# Altium schematic sheet Sheet2.SchDoc
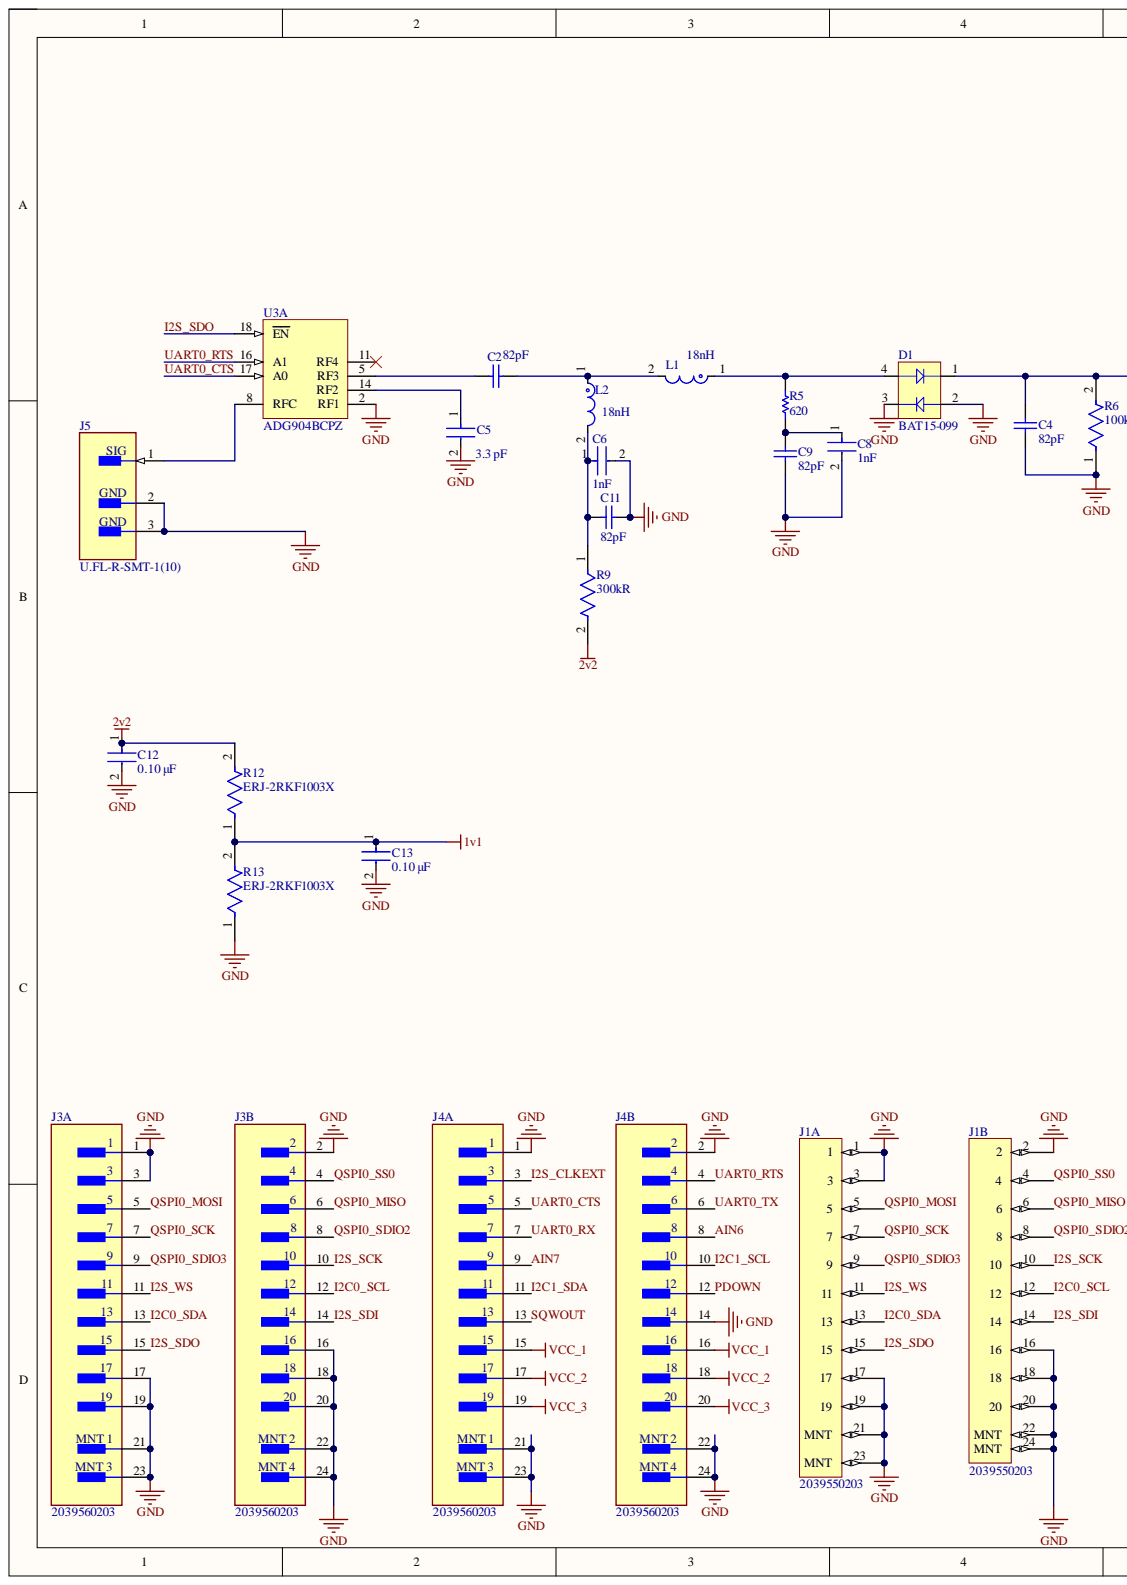
[diagram p0: Sheet2.SchDoc, left]
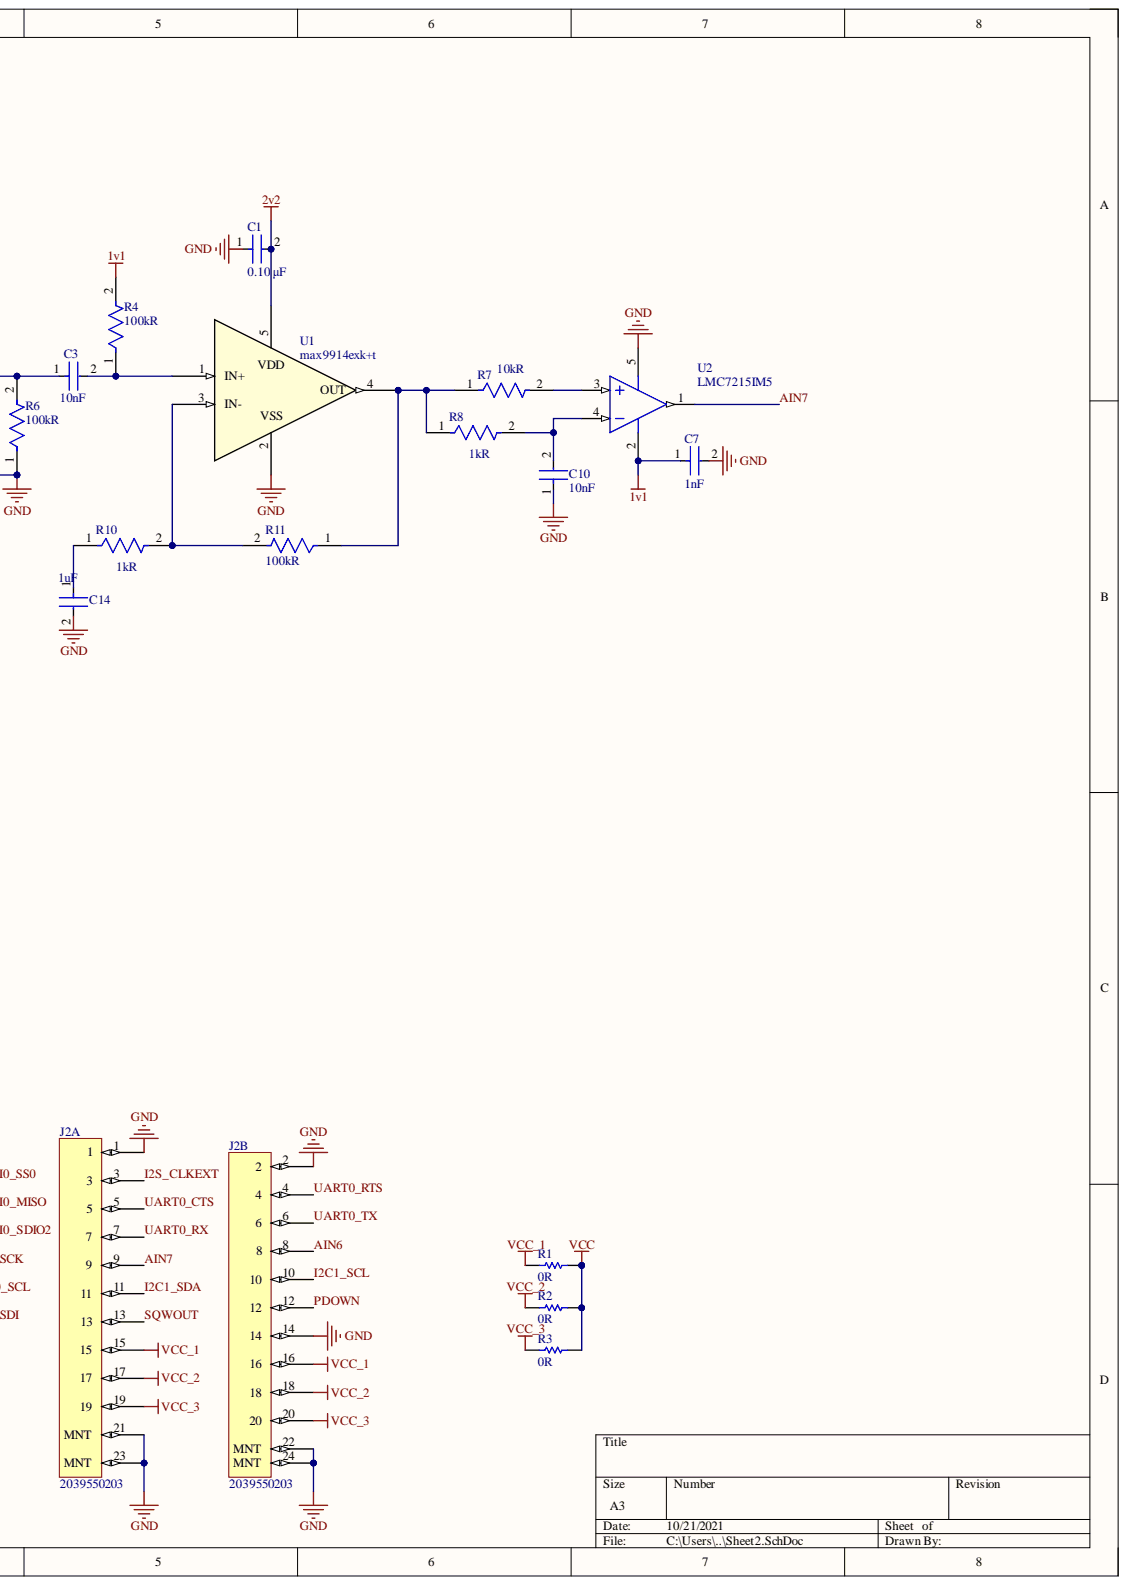
[diagram p1: Sheet2.SchDoc, right]

## Parts
C1  value 0.10 μF  Capacitance: 0.10 μF  [FP-C0402-BB-MFG]  (hidden comment: C0402C104K8PACTU)
C2  value 82pF  [CAPC1005X55X25ML05T10]  (hidden comment: GRM1555C1H820JA01D)
C3  Capacitance: 10nF  [FP-CL165-IPC_C]  (hidden comment: CL05B103KB5NNNC)
C4  value 82pF  [CAPC1005X55X25ML05T10]  (hidden comment: GRM1555C1H820JA01D)
C5  value 3.3 pF  Capacitance: 3.3 pF  [FP-C0402-BB-MFG]  (hidden comment: C0402C339C5GACTU)
C6  Capacitance: 1nF  [FP-GRM155-0_05-IPC_B]  (hidden comment: GRM1555C1H102JA01D)
C7  Capacitance: 1nF  [FP-GRM155-0_05-IPC_B]  (hidden comment: GRM1555C1H102JA01D)
C8  Capacitance: 1nF  [FP-GRM155-0_05-IPC_B]  (hidden comment: GRM1555C1H102JA01D)
C9  value 82pF  [CAPC1005X55X25ML05T10]  (hidden comment: GRM1555C1H820JA01D)
C10  Capacitance: 10nF  [FP-CL165-IPC_C]  (hidden comment: CL05B103KB5NNNC)
C11  value 82pF  [CAPC1005X55X25ML05T10]  (hidden comment: GRM1555C1H820JA01D)
C12  value 0.10 μF  Capacitance: 0.10 μF  [FP-C0402-BB-MFG]  (hidden comment: C0402C104K8PACTU)
C13  value 0.10 μF  Capacitance: 0.10 μF  [FP-C0402-BB-MFG]  (hidden comment: C0402C104K8PACTU)
C14  Capacitance: 1uF  [FP-C0402C105K8PACTU-MFG]  (hidden comment: C0402C105K8PACTU)
D1  BAT15-099  [INF-SOT143-4-1_V]
J1  2039550203  [2039550203]  (parts A,B)
J2  2039550203  [2039550203]  (parts A,B)
J3  2039560203  [Molex_0_MFG]  (parts A,B)
J4  2039560203  [Molex_0_MFG]  (parts A,B)
J5  U.FL-R-SMT-1(10)  [FP-U_FL-R-SMT-1_10-MFG]
L1  Inductance: 18nH  [FP-LQG15HH_02-IPC_A]  (hidden comment: LQG15HN18NJ02D)
L2  Inductance: 18nH  [FP-LQG15HH_02-IPC_A]  (hidden comment: LQG15HN18NJ02D)
R1  0R  [RESC1005X40X25NL05T10]
R2  0R  [RESC1005X40X25NL05T10]
R3  0R  [RESC1005X40X25NL05T10]
R4  ERJ-2RKF1003X  Resistance: 100kR  [FP-ERJ2RK-IPC_C]
R5  620  [RESC1005X40X25NL05T05]
R6  ERJ-2RKF1003X  Resistance: 100kR  [FP-ERJ2RK-IPC_C]
R7  Resistance: 10kR  [FP-CRCW0402-e3-IPC_B]  (hidden comment: CRCW040210K0FKED)
R8  Resistance: 1kR  [FP-CRCW0402-e3-MFG]  (hidden comment: CRCW04021K00FKED)
R9  Resistance: 300kR  [FP-CRCW0402-e3-IPC_C]  (hidden comment: CRCW0402300KFKED)
R10  Resistance: 1kR  [FP-CRCW0402-e3-MFG]  (hidden comment: CRCW04021K00FKED)
R11  ERJ-2RKF1003X  Resistance: 100kR  [FP-ERJ2RK-IPC_C]
R12  ERJ-2RKF1003X  [FP-ERJ2RK-IPC_C]
R13  ERJ-2RKF1003X  [FP-ERJ2RK-IPC_C]
U1  max9914exk+t  [21-0076E_MXM]
U2  LMC7215IM5  [DBV0005A_N]
U3  ADG904BCPZ  [ADI-CP-20-6_N]  (part A)

## Nets
1v1: C7.1 C13.1 R4.2 R12.1 R13.2 U2.2
2v2: C1.2 C12.1 R9.2 R12.2 U1.5
GND: C1.1 C4.1 C5.2 C6.2 C7.2 C8.2 C9.1 C10.1 C11.2 C12.2 C13.2 C14.2 D1.2 D1.3 J1.1 J1.2 J1.3 J1.16 J1.17 J1.18 J1.19 J1.20 J1.21(MNT) J1.22(MNT) J1.23(MNT) J1.24(MNT) J2.1 J2.2 J2.14 J2.21(MNT) J2.22(MNT) J2.23(MNT) J2.24(MNT) J3.1 J3.2 J3.3 J3.16 J3.17 J3.18 J3.19 J3.20 J3.21 J3.22 J3.23 J3.24 J4.1 J4.2 J4.14 J4.21 J4.22 J4.23 J4.24 J5.2 J5.3 R6.1 R13.1 U1.2 U2.5 U3.2(RF1)
VCC: R1.2 R2.2 R3.2
VCC_1: J2.15 J2.16 J4.15 J4.16 R1.1
VCC_2: J2.17 J2.18 J4.17 J4.18 R2.1
VCC_3: J2.19 J2.20 J4.19 J4.20 R3.1
AIN6: J2.8 J4.8
AIN7: J2.9 J4.9 U2.1
I2C0_SCL: J1.12 J3.12
I2C0_SDA: J1.13 J3.13
I2C1_SCL: J2.10 J4.10
I2C1_SDA: J2.11 J4.11
I2S_CLKEXT: J2.3 J4.3
I2S_SCK: J1.10 J3.10
I2S_SDI: J1.14 J3.14
I2S_SDO: J1.15 J3.15 U3.18(EN)
I2S_WS: J1.11 J3.11
PDOWN: J2.12 J4.12
QSPI0_MISO: J1.6 J3.6
QSPI0_MOSI: J1.5 J3.5
QSPI0_SCK: J1.7 J3.7
QSPI0_SDIO2: J1.8 J3.8
QSPI0_SDIO3: J1.9 J3.9
QSPI0_SS0: J1.4 J3.4
SQWOUT: J2.13 J4.13
UART0_CTS: J2.5 J4.5 U3.17(A0)
UART0_RTS: J2.4 J4.4 U3.16(A1)
UART0_RX: J2.7 J4.7
UART0_TX: J2.6 J4.6
NetC2_1: C2.1 U3.5(RF3)
NetC2_2: C2.2 L1.2 L2.1
NetC3_1: C3.1 C4.2 D1.1 R6.2
NetC3_2: C3.2 R4.1 U1.1(IN+)
NetC5_1: C5.1 U3.14(RF2)
NetC6_1: C6.1 C11.1 L2.2 R9.1
NetC8_1: C8.1 C9.2 R5.1
NetC10_2: C10.2 R8.2 U2.4
NetC14_1: C14.1 R10.1
NetD1_4: D1.4 L1.1 R5.2
NetJ5_1: J5.1 U3.8(RFC)
NetR7_1: R7.1 R8.1 R11.1 U1.4(OUT)
NetR7_2: R7.2 U2.3
NetR10_2: R10.2 R11.2 U1.3(IN-)

Not connected: U3 11(RF4)

## Library data of the parts
C1 C12 C13 = CMP-2008-02046-2: CAP CER 0.1UF 10V X5R 0402; Manufacturer: Kemet; Material: Ceramic; Temperature Coefficient: 15%; Tolerance: 0.1; Automotive: No; Category: Cap; Component Kind: Standard; ComponentLink2Description: Datasheet; ComponentLink2URL: https://content.kemet.com/datasheets/KEM_C1002_X7R_SMD.pdf; Device Class L1: Passive Components; Device Class L2: Capacitors; Device Class L3: Ceramic Capacitors; Digikey Description: CAP CER 0.1UF 10V X5R 0402; Height: 0.55mm; Ipc Land Pattern Name: CAPC10050X50; Lead Free: Yes; Library Name: Altium Content Vault; Manufacturer Part Number: C0402C104K8PACTU; Mouser Description: Capacitor 100 nF 10 VDC 0402; Octopart: https://octopart.com/c0402c104k8pactu-kemet-140039; Package: C0402-BB; RoHS: TRUE; Temperature: -55°C to +125°C; Temperature Characteristic: X5R; Temperature Range High: +125°C; Temperature Range Low: -55°C; Voltage Rating: 10 V
C2 C4 C9 C11 = CMP-2008-04442-1: Manufacturer: Murata; Component Kind: Standard; Library Name: Altium Content Vault; Part Number: GRM1555C1H820JA01D
C3 C10 = CMP-13271-000387-1: Cap Ceramic 10nF 50V X7R ±10% SMD 0402 +125°C Paper T/R; Case Code (Imperial): 0402; Case Code (Metric): 1005; Case/Package: 0402; Component Kind: Standard; Contact Plating: Tin; Depth: 0.5mm; Dielectric: X7R; Height: 0.5mm; Lead Free: Lead Free; Length: 1mm; Library Name: Altium Content Vault; Material: Ceramic; Max Operating Temperature: 125°C; Min Operating Temperature: -55°C; Mount: Surface Mount; Package Quantity: 1; Packaging: Tape and Reel; Radiation Hardening: No; Thickness: 0.022inch; Tolerance: 10%; Voltage: 50V; Voltage Rating: 50V; Voltage Rating (DC): 50V; Width: 0.02inch
C5 = CMP-2008-03312-2: Capacitor: ceramic; MLCC; 3.3pF; 50VDC; C0G; ±0.25pF; SMD; 0402; Manufacturer: Kemet; Temperature Coefficient: 15%; Voltage Rating: 50 V; Automotive: No; Category: Cap; Component Kind: Standard; ComponentLink2Description: Datasheet; ComponentLink2URL: https://content.kemet.com/datasheets/KEM_C1002_X7R_SMD.pdf; Device Class L1: Passive Components; Device Class L2: Capacitors; Device Class L3: Ceramic Capacitors; Digikey Description: Capacitor: ceramic; MLCC; 3.3pF; 50VDC; C0G; ±0.25pF; SMD; 0402; Height: 0.55mm; Ipc Land Pattern Name: CAPC10050X50; Lead Free: Yes; Library Name: Altium Content Vault; Manufacturer Part Number: C0402C339C5GACTU; Material: Ceramic; Mouser Description: Multilayer Ceramic Capacitors MLCC - SMD/SMT 50volts 3.3pF C0G .25pF; Octopart: https://octopart.com/c0402c339c5gactu-kemet-105751; Package: C0402-BB; RoHS: TRUE; Temperature: -55°C to +125°C; Temperature Characteristic: C0G; Temperature Range High: +125°C; Temperature Range Low: -55°C; Tolerance: 0.25 pF
C6-C8 = CMP-2008-04254-2: Chip Multilayer Ceramic Capacitors for General Purpose, 0402, 1000pF, C0G, 30ppm/°C, 5%, 50V; Insulation Resistance: 10GR; Case Code (Imperial): 0402; Case Code (Metric): 1005; Case/Package: 0402; Component Kind: Standard; Depth: 0.5mm; Dielectric: C0G; Dielectric Material: Ceramic; Height: 0.5mm; Lead Free: Lead Free; Length: 1mm; Library Name: Altium Content Vault; Material: Ceramic; Max Operating Temperature: 125°C; Min Operating Temperature: -55°C; Mount: Surface Mount; Number of Pins: 2; Package Quantity: 1; Packaging: Tape and Reel; Radiation Hardening: No; REACH SVHC: No SVHC; Temperature Coefficient: 30ppm/°C; Termination: SMD/SMT; Thickness: 0.022inch; Tolerance: 5%; Voltage: 50V; Voltage Rating: 50V; Voltage Rating (DC): 50V; Width: 0.5mm
C14 = CMP-2008-02081-2: CAP CER 1UF 10V X5R 0402; Dielectric Material: Ceramic; Dissipation Factor: 5%; Tolerance: 10%; Case Code (Imperial): 0402; Case Code (Metric): 1005; Case/Package: 0402; Component Kind: Standard; Construction: Flat; Contact Plating: Tin; Depth: 0.5mm; Dielectric: X5R; Height: 0.5mm; Lead Free: Lead Free; Length: 1mm; Library Name: Altium Content Vault; Max Operating Temperature: 85°C; Min Operating Temperature: -55°C; Mount: Surface Mount; Number of Pins: 2; Package Quantity: 1; Packaging: Tape and Reel; Radiation Hardening: No; REACH SVHC: No SVHC; RoHS Compliant: Yes; Series: C; Termination: SMD/SMT; Thickness: 0.022inch; Voltage: 10V; Voltage Rating: 10V; Voltage Rating (DC): 10V; Width: 0.5mm
D1 = CMP-1154-00009-1: Single Silicon Schottky Diode, 110 mA IF Max, SOT143-4-1, Green; Manufacturer: Infineon; CT (typ): 0.26 pF; Green: yes; Group: RF Mixer; IF (max): 110 mA; VF (typ): 0.23 V; VR (max): 4 V; Component Kind: Standard; ComponentLink1Description: Manufacturer URL; ComponentLink1URL: http://www.infineon.com/; ComponentLink2Description: Datasheet; ComponentLink2URL: http://www.infineon.com/dgdl/bat15series.pdf?folderId=db3a304314dca3890114ff6812070b44&fileId=db3a304314dca3890114ff6b74ca0b48&location=en.Products.RF.RF_Mixer___Detector_Schottky_Diodes.DATASHEET.bat15series.pdf; ComponentLink3Description: Package Specification; ComponentLink3URL: http://www.infineon.com/dgdl/SOT143-Package_Overview.pdf?folderId=db3a30431936bc4b011952ce8b1b3bed&fileId=db3a30431936bc4b011952df42203c0c; Configuration: Single; DatasheetVersion: Jun-2011; Library Name: Altium Content Vault; Mounting Technology: Surface Mount; PackageDescription: 4-Pin Small Outline Transistor Package, 1.3 x 2.9 mm Body; PackageReference: SOT143-4-1; PartNumber: BAT15-099
J3 J4 = CMP-003-000000-1: 20 Position Connector Plug, Outer Shroud Contacts Surface Mount Gold; Manufacturer: Molex; Conn Gender: Male; Conn Orientation: Vertical; Current Rating: 0.3A; Is Female: no; Number Of Contacts: 20; Pitch: 0.4mm; Symbol Hash: db22f1623fbfed54a22177e49599976dea34d6b12f98f445fc170047d3fb1d12; Verification Version: 0.0.0.3; Voltage Rating: 40V; Automotive: No; Component Kind: Standard; ComponentLink1Description: Upverter; ComponentLink1URL: https://upverter.com/part/id/0a58283e91808ac4/; ComponentLink2Description: Datasheet; ComponentLink2URL: https://www.molex.com/webdocs/datasheets/pdf/en-us/2039560203_PCB_HEADERS.pdf; Contact Material: Gold,Nickel; Datasheet Path: datasheets/Conn_Molex_2039560203_eec.pdf; Digikey Description: CONN PLUG 20POS SMD GOLD; Digikey Part Number: 900-2039560203CT-ND; Footprint Url: https://www.molex.com/pdm_docs/sd/2039560203_sd.pdf; Height: 4.55mm; helpURL: https://upverter.com/part/id/0a58283e91808ac4/; Lead Free: Yes; Mouser Part Number: 538-203956-0203; Number Of Rows: 2; Package: 0; Part Number: 2039560203; RoHS: TRUE; Temperature: -40°C to +125°C; Temperature Range High: +125°C; Temperature Range Low: -40°C; Library Name: Supersensor
J5 = CMP-2000-05152-3: CONN U.FL RCPT STR 50 OHM SMD; Body Material: Bronze; Body Plating: Silver; Connector Type: Coaxial; Dielectric Material: Polymer; Frequency: 6GHz; Gender: Male; Impedance: 50R; Insulation Resistance: 500MR; Component Kind: Standard; Contact Material: Brass; Contact Plating: Gold; Depth: 3.1mm; Fastening Type: Snap; Housing Color: Beige; Lead Free: Lead Free; Length: 3mm; Library Name: Altium Content Vault; Max Frequency: 6GHz; Max Operating Temperature: 90°C; Min Operating Temperature: -40°C; Mount: Surface Mount; Orientation: Straight; Package Quantity: 2500; Packaging: Cut Tape; Radiation Hardening: No; REACH SVHC: No SVHC; Series: U.FL; Termination: Solder; Weight: 0.001764oz; Wire/Cable Type: Coaxial
L1 L2 = CMP-06042-010999-1: Multilayer type RF Inductor 18nH ±5% 0.51Ω 350mA 0402; Core Material: Air; Current Rating: 300mA; DC Resistance (DCR): 510mR; Q Factor: 8; Self Resonant Frequency: 2.1GHz; Shielding: Unshielded; Test Frequency: 100MHz; Case Code (Imperial): 0402; Case Code (Metric): 1005; Case/Package: 0402; Component Kind: Standard; DC Current: 300mA; Depth: 0.55mm; Height: 0.5mm; Height - Seated (Max): 0.022inch; Inductance Tolerance: 5%; Lead Free: Lead Free; Length: 1mm; Library Name: Altium Content Vault; Max DC Current: 300mA; Max Operating Temperature: 125°C; Military Standard: Not; Min Operating Temperature: -55°C; Mount: Surface Mount; Package Quantity: 1; Packaging: Tape and Reel; Radiation Hardening: No; REACH SVHC: No SVHC; Termination: SMD/SMT; Tolerance: 5%; Width: 0.5mm
R1-R3 = CMP-1011-00001-2: Manufacturer: Yageo / Phycomp; Part Number: RC0402JR-070RL; Component Kind: Standard; Library Name: Altium Content Vault
R4 R6 R11 = CMP-2002-00608-4: RES SMD 100K OHM 1% 1/10W 0402; Max Power Dissipation: 100mW; Temperature Coefficient: 100ppm/°C; Voltage Rating: 50V; Case Code (Imperial): 0402; Case Code (Metric): 1005; Case/Package: 0402; Component Kind: Standard; Composition: Thick Film; Contact Plating: Tin; Depth: 0.5mm; Features: Automotive AEC-Q200; Height: 0.35mm; Lead Free: Lead Free; Length: 1mm; Library Name: Altium Content Vault; Max Operating Temperature: 155°C; Military Standard: Not; Min Operating Temperature: -55°C; Mount: Surface Mount; Number of Pins: 2; Number of Terminations: 2; Package Quantity: 1; Packaging: Tape and Reel; Power Rating: 100mW; Radiation Hardening: No; REACH SVHC: No SVHC; Termination: SMD/SMT; Tolerance: 1%; Voltage Rating (DC): 50V; Width: 0.5mm
R5 = CMP-2002-01019-1: Manufacturer: Panasonic; Part Number: ERJ-2RKF6200X; Component Kind: Standard; Library Name: Altium Content Vault
R7 = CMP-2002-08135-2: RES SMD 10K OHM 1% 1/16W 0402; Max Power Dissipation: 63mW; Temperature Coefficient: 100ppm/°C; Voltage Rating: 50V; Case Code (Imperial): 0402; Case Code (Metric): 1005; Case/Package: 0402; Component Kind: Standard; Composition: Thick Film; Contact Plating: Tin; Depth: 0.5mm; Features: Automotive AEC-Q200; Height: 0.35mm; Lead Free: Lead Free; Length: 1mm; Library Name: Altium Content Vault; Max Operating Temperature: 155°C; Military Standard: Not; Min Operating Temperature: -55°C; Mount: Surface Mount; Number of Pins: 2; Number of Terminations: 2; Package Quantity: 10; Packaging: Tape and Reel; Power Rating: 63mW; Radiation Hardening: No; Termination: SMD/SMT; Tolerance: 1%; Voltage Rating (DC): 50V; Weight: 2.3E-05oz; Width: 0.02inch
R8 R10 = CMP-2002-08276-2: RES Thick Film, 1kΩ, 1%, 0.063W, 100ppm/°C, 0402; Max Power Dissipation: 63mW; Voltage Rating: 50V; Case Code (Imperial): 0402; Case Code (Metric): 1005; Case/Package: 0402; Component Kind: Standard; Composition: Thick Film; Contact Plating: Tin; Depth: 0.5mm; Features: Automotive AEC-Q200; Height: 0.35mm; Lead Free: Lead Free; Length: 1mm; Library Name: Altium Content Vault; Max Operating Temperature: 155°C; Military Standard: Not; Min Operating Temperature: -55°C; Mount: Surface Mount; Number of Pins: 2; Number of Terminations: 2; Package Quantity: 10; Packaging: Tape and Reel; Power Rating: 63mW; Radiation Hardening: No; Temperature Coefficient: 100ppm/°C; Termination: SMD/SMT; Tolerance: 1%; Voltage Rating (DC): 50V; Weight: 2.3E-05oz; Width: 0.5mm
R9 = CMP-2002-08495-2: RES Thick Film, 300kΩ, 1%, 0.063W, 100ppm/°C, 0402; Max Power Dissipation: 63mW; Voltage Rating: 50V; Case Code (Imperial): 0402; Case Code (Metric): 1005; Case/Package: 0402; Component Kind: Standard; Composition: Thick Film; Contact Plating: Tin; Depth: 0.5mm; Features: Automotive AEC-Q200; Height: 0.35mm; Lead Free: Lead Free; Length: 1mm; Library Name: Altium Content Vault; Max Operating Temperature: 155°C; Military Standard: Not; Min Operating Temperature: -55°C; Mount: Surface Mount; Number of Pins: 2; Number of Terminations: 2; Package Quantity: 10; Packaging: Tape and Reel; Power Rating: 63mW; Radiation Hardening: No; Temperature Coefficient: 100ppm/°C; Termination: SMD/SMT; Tolerance: 1%; Voltage Rating (DC): 50V; Weight: 2.3E-05oz; Width: 0.02inch
R12 R13 = CMP-2002-00608-4: RES SMD 100K OHM 1% 1/10W 0402; Max Power Dissipation: 100mW; Resistance: 100kR; Temperature Coefficient: 100ppm/°C; Voltage Rating: 50V; Case Code (Imperial): 0402; Case Code (Metric): 1005; Case/Package: 0402; Component Kind: Standard; Composition: Thick Film; Contact Plating: Tin; Depth: 0.5mm; Features: Automotive AEC-Q200; Height: 0.35mm; Lead Free: Lead Free; Length: 1mm; Library Name: Altium Content Vault; Max Operating Temperature: 155°C; Military Standard: Not; Min Operating Temperature: -55°C; Mount: Surface Mount; Number of Pins: 2; Number of Terminations: 2; Package Quantity: 1; Packaging: Tape and Reel; Power Rating: 100mW; Radiation Hardening: No; REACH SVHC: No SVHC; Termination: SMD/SMT; Tolerance: 1%; Voltage Rating (DC): 50V; Width: 0.5mm
U1 = max9914exk+t: No Description Available; Built_By: EMA_UL_Team; SourceLibrary: Maxim_2009-12-17_3b; Vendor: Maxim Integrated Products; Component Kind: Standard; Library Name: max9914exk&plus_t.IntLib; Manufacturer_Part_Number: max9914exk+t; RefDes: RefDes; Type: DEV; Copyright: Copyright (C) 2021 Accelerated Designs. All rights reserved
U2 = CMP-0055-01229-3: Micro-Power, Rail-to-Rail CMOS Comparators with Open-Drain/Push-Pull Outputs, 5-pin SOT-23; Manufacturer: Texas Instruments; CompType: Amplifiers; PackageTopMarking: C02B*XTT; PowerWise: Y; Component Kind: Standard; ComponentLink1Description: Datasheet URL; ComponentLink1URL: http://www.ti.com/general/docs/lit/getliterature.tsp?genericPartNumber=LMC7215&fileType=pdf; Library Name: Altium Content Vault; Package Reference: DBV0005A; PackageDescription: Molded SOT-23, .115 x .063 x .040 in Body, 5 Lead, .0375 in Pitch; PinCount: 5; RoHS: N
U3 = CMP-0290-00002-2: Wideband 2.5 GHz, 37 dB Isolation at 1 GHz, CMOS 1.65 to 2.75 V, 4:1 Mux / SP4T, -40 to 85 degC, 20-pin QFN (CP-20-1), Tray; Manufacturer: Analog Devices; Bandwidth (Hz): 2.5G; Supply V Guar Performance: Single_(+2.5V); t transition (s): 12n; Code_JEDEC: MO-220; Component Kind: Standard; ComponentLink1Description: Manufacturer URL; ComponentLink1URL: http://www.analog.com/en/index.html; ComponentLink2Description: Datasheet; ComponentLink2URL: http://www.analog.com/static/imported-files/data_sheets/ADG904_904R.pdf; ComponentLink3Description: Package Specification; ComponentLink3URL: http://www.analog.com/static/imported-files/packages/PKG_PDF/LFCSP(CP)/CP_20_6.pdf; DatasheetVersion: Rev. B; Library Name: Altium Content Vault; Mounting Technology: Surface Mount; PackageDescription: 20-Pin Lead Frame Chip Scale Package, Body 4 x 4 mm, Pitch 0.5 mm; PackageReference: CP-20-6; PackageVersion: 061708-A, 07/2011; Packing: Tray; PartNumber: ADG904BCPZ
J1 J2 = 2039550203: Component Kind: Standard; Library Name: Molex_conn.IntLib
